# Altium schematic sheet page1.SchDoc(page1)
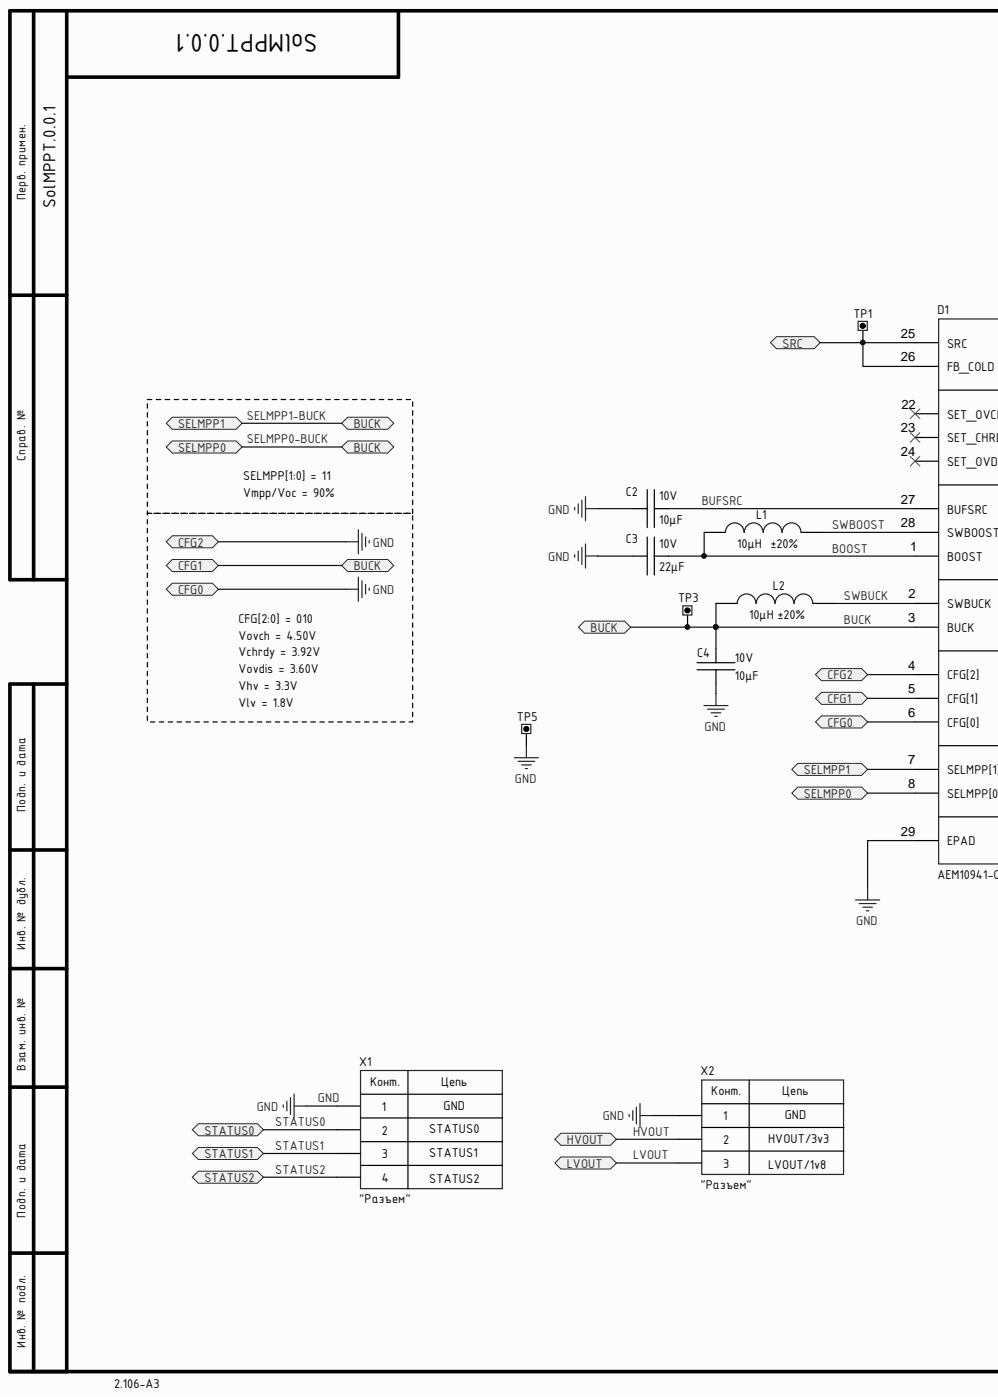
[diagram p0: page1.SchDoc, left]
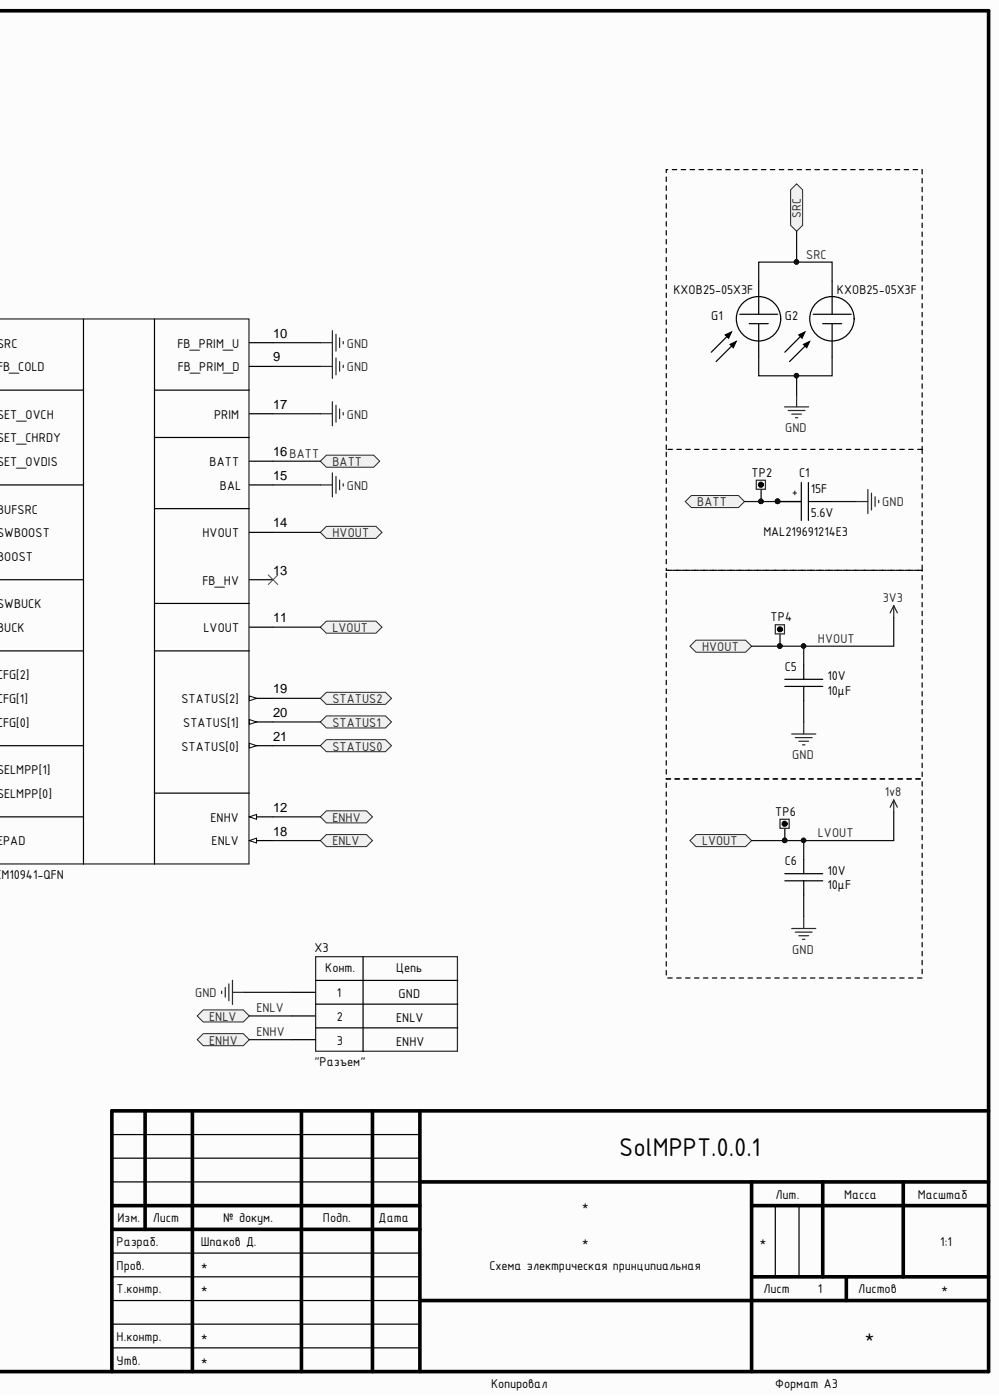
[diagram p1: page1.SchDoc, right]

## Parts
C1  15F  Voltage: 5.6V  [MAL219691214E3]
C2  value 10μF  Voltage: 10V  [CAP: 0603]  (hidden comment: Ceramic capacitor, 10μF, X5R, ±20%, 10V, 0603, -55...+85°C)
C3  value 22μF  Voltage: 10V  [CAP: 0603]  (hidden comment: Ceramic capacitor, 22μF, X5R, ±20%, 10V, 0603, -55...+85°C)
C4  value 10μF  Voltage: 10V  [CAP: 0603]  (hidden comment: Ceramic capacitor, 10μF, X5R, ±20%, 10V, 0603, -55...+85°C)
C5  value 10μF  Voltage: 10V  [CAP: 0603]  (hidden comment: Ceramic capacitor, 10μF, X5R, ±20%, 10V, 0603, -55...+85°C)
C6  value 10μF  Voltage: 10V  [CAP: 0603]  (hidden comment: Ceramic capacitor, 10μF, X5R, ±20%, 10V, 0603, -55...+85°C)
D1  AEM10941-QFN  [QFN50P500X500X85_HS-29N]
G1  KXOB25-05X3F  [KXOB25-05X3F]
G2  KXOB25-05X3F  [KXOB25-05X3F]
L1  value 10μH  Tolerance: ±20%  [IND: SDR0302]  (hidden comment: Fixed inductor, 10μH, ±20%, SDR0302, 900mA, -40...+125°C)
L2  value 10μH  Tolerance: ±20%  [IND: 0603]  (hidden comment: Fixed inductor, 10μH, ±20%, 0603, 250mA, -55...+125°C)
TP1  Test point, Keystone, 5001  [CON: 5000 (Keystone)]  (value not drawn)
TP2  Test point, Keystone, 5001  [CON: 5000 (Keystone)]  (value not drawn)
TP3  Test point, Keystone, 5001  [CON: 5000 (Keystone)]  (value not drawn)
TP4  Test point, Keystone, 5001  [CON: 5000 (Keystone)]  (value not drawn)
TP5  Test point, Keystone, 5001  [CON: 5000 (Keystone)]  (value not drawn)
TP6  Test point, Keystone, 5001  [CON: 5000 (Keystone)]  (value not drawn)
X1  CommentText: "Разъем"  [CON: PLS-4]  (hidden comment: Connector, 4pin, 2.54mm, 500VAC, 3A)
X2  CommentText: "Разъем"  [CON: PLS-3]  (hidden comment: Connector, 3pin, 2.54mm, 500VAC, 3A)
X3  CommentText: "Разъем"  [CON: PLS-3]  (hidden comment: Connector, 3pin, 2.54mm, 500VAC, 3A)

## Ports
BATT, BUCK, CFG0, CFG1, CFG2, ENHV, ENLV, HVOUT, LVOUT, SELMPP0, SELMPP1, SRC, STATUS0, STATUS1, STATUS2

## Nets
BATT: C1.1 C1.1a D1.16(BATT) TP2.1 ; port BATT
BOOST: C3.1 D1.1(BOOST) L1.2
BUCK: C4.1 D1.3(BUCK) D1.5(CFG[1]) D1.7(SELMPP[1]) D1.8(SELMPP[0]) L2.2 TP3.1 ; port BUCK, port CFG1, port SELMPP0, port SELMPP1
BUFSRC: C2.2 D1.27(BUFSRC)
ENHV: D1.12(ENHV) X3.3 ; port ENHV
ENLV: D1.18(ENLV) X3.2 ; port ENLV
GND: C1.2 C2.1 C3.2 C4.2 C5.2 C6.2 D1.4(CFG[2]) D1.6(CFG[0]) D1.9(FB_PRIM_D) D1.10(FB_PRIM_U) D1.15(BAL) D1.17(PRIM) D1.29(EPAD) G1.2 G2.2 TP5.1 X1.1 X2.1 X3.1 ; port CFG0, port CFG2
HVOUT: C5.1 D1.14(HVOUT) TP4.1 X2.2 ; port HVOUT
LVOUT: C6.1 D1.11(LVOUT) TP6.1 X2.3 ; port LVOUT
SRC: D1.25(SRC) D1.26(FB_COLD) G1.1 G2.1 TP1.1 ; port SRC
STATUS0: D1.21(STATUS[0]) X1.2 ; port STATUS0
STATUS1: D1.20(STATUS[1]) X1.3 ; port STATUS1
STATUS2: D1.19(STATUS[2]) X1.4 ; port STATUS2
SWBOOST: D1.28(SWBOOST) L1.1
SWBUCK: D1.2(SWBUCK) L2.1

Not connected: D1 13(FB_HV) 22(SET_OVCH) 23(SET_CHRDY) 24(SET_OVDIS)

## Library data of the parts
C1 = MAL219691214E3: CAP 15F -20% +80% 5.6V T/H; Manufacturer: Vishay Beyschlag/Draloric/BC Components; Lifetime: 1000Hrs @ 85C; Kind: Supercapacitor; ESR: 2.4Ohm @ 1kHz; Value: 15F; PartNumber: MAL219691214E3; Package: Coin, Stacked Horizontal; OperatingTemperature: -20...85C; MountingType: TH; Library Name: MAL219691214E3.IntLib; Component Kind: Standard
C2 C4-C6 = Ceramic_0603: PartNumber: GRM188R61A106ME69D; Manufacturer: Murata Electronics; ValueManufacturerPartNumber: Ceramic capacitor, 10μF, X5R, ±20%, 10V, 0603, -55...+85°C; TypeDielectric: X5R; TypeCapacitor: Ceramic capacitor; Tolerance: ±20%; Temperature: -55...+85°C; Package: 0603; Library Name: capacitor.IntLib; HelpURL: https://www.digikey.com/en/products/detail/murata-electronics/GRM188R61A106ME69D/5027560; Component Kind: Standard
C3 = Ceramic_0603: PartNumber: GRM188R61A226ME15D; Manufacturer: Murata Electronics; ValueManufacturerPartNumber: Ceramic capacitor, 22μF, X5R, ±20%, 10V, 0603, -55...+85°C; TypeDielectric: X5R; TypeCapacitor: Ceramic capacitor; Tolerance: ±20%; Temperature: -55...+85°C; Package: 0603; Library Name: capacitor.IntLib; HelpURL: https://www.digikey.com/en/products/detail/murata-electronics/GRM188R61A226ME15D/5027561; Component Kind: Standard
D1 = AEM10941: Power Management Specialised - PMIC AEM10941 IC - Photovoltaic Energy Harvesting Manager.; Manufacturer: e-peas; OperationTemperature: -40...+125°C; Type: Photovoltaic Energy Harvesting Manager; PartNumber: AEM10941-QFN; Library Name: AEM10941.IntLib; HelpURL: https://eu.mouser.com/ProductDetail/e-peas/AEM10941-QFN/?qs=W%2FMpXkg%252BdQ7CeqB2lBPbRQ%3D%3D; Component Kind: Standard; Case: QFN-28
G1 G2 = KXOB25-05X3F: Monocrystalline solar cell.; Manufacturer: ANYSOLAR Ltd; Voc: 2.07V; Vmpp: 1.67V; Pmpp: 30.7mW; Isc: 19.5mA; Impp: 18.4mA; Efficiency: 25%; PartNumber: KXOB25-05X3F; Library Name: Solar_cell.IntLib; HelpURL: https://waf-e.dubudisk.com/anysolar.dubuplus.com/anonymous/O18AjDa/DubuDisk/public/KXOB25-05X3F%20DATA%20SHEET%20202007.pdf; Component Kind: Standard
L1 = Inductor_SDR0302: PartNumber: SDR0302-100ML; Manufacturer: Bourns; ValueManufacturerPartNumber: Fixed inductor, 10μH, ±20%, SDR0302, 900mA, -40...+125°C; TypeInductor: Fixed inductor; Temperature: -40...+125°C; Package: SDR0302; MaxCurrent: 900mA; Library Name: inductor.IntLib; HelpURL: https://www.digikey.com/product-detail/en/bourns-inc/SDR0302-100ML/SDR0302-100MLCT-ND/2127048; Component Kind: Standard
L2 = Inductor_0603: PartNumber: MLZ1608M100WT000; Manufacturer: TDK Corporation; ValueManufacturerPartNumber: Fixed inductor, 10μH, ±20%, 0603, 250mA, -55...+125°C; TypeInductor: Fixed inductor; Temperature: -55...+125°C; Package: 0603; MaxCurrent: 250mA; Library Name: inductor.IntLib; HelpURL: https://www.digikey.com/en/products/detail/tdk-corporation/MLZ1608M100WT000/2465148; Component Kind: Standard
TP1-TP6 = Connector_Test_Point: PartNumber: 5001; ValueManufacturerPartNumber: Test point, Keystone, 5001; TypeConnector: Test point; Manufacturer: Keystone; Library Name: connector.IntLib; HelpURL: https://www.digikey.com/product-detail/en/keystone-electronics/5001/36-5001-ND/255327; Component Kind: Standard
X1 = PLS-4: Manufacturer: Connfly; VoltageMax: 500VAC; ValueManufacturerPartNumber: Connector, 4pin, 2.54mm, 500VAC, 3A; TypeConnector: Connector; Pitch: 2.54mm; PartNumber: PLS-4; Multichannel: 4pin; Library Name: connector.IntLib; HelpURL: https://www.chipdip.ru/product/pls-4; CurrentMax: 3A; Component Kind: Standard
X2 X3 = PLS-3: Manufacturer: Connfly; VoltageMax: 500VAC; ValueManufacturerPartNumber: Connector, 3pin, 2.54mm, 500VAC, 3A; TypeConnector: Connector; Pitch: 2.54mm; PartNumber: PLS-3; Multichannel: 3pin; Library Name: connector.IntLib; HelpURL: https://www.chipdip.ru/product/pls-3; CurrentMax: 3A; Component Kind: Standard
